# Altium schematic sheet Schematic.SchDoc
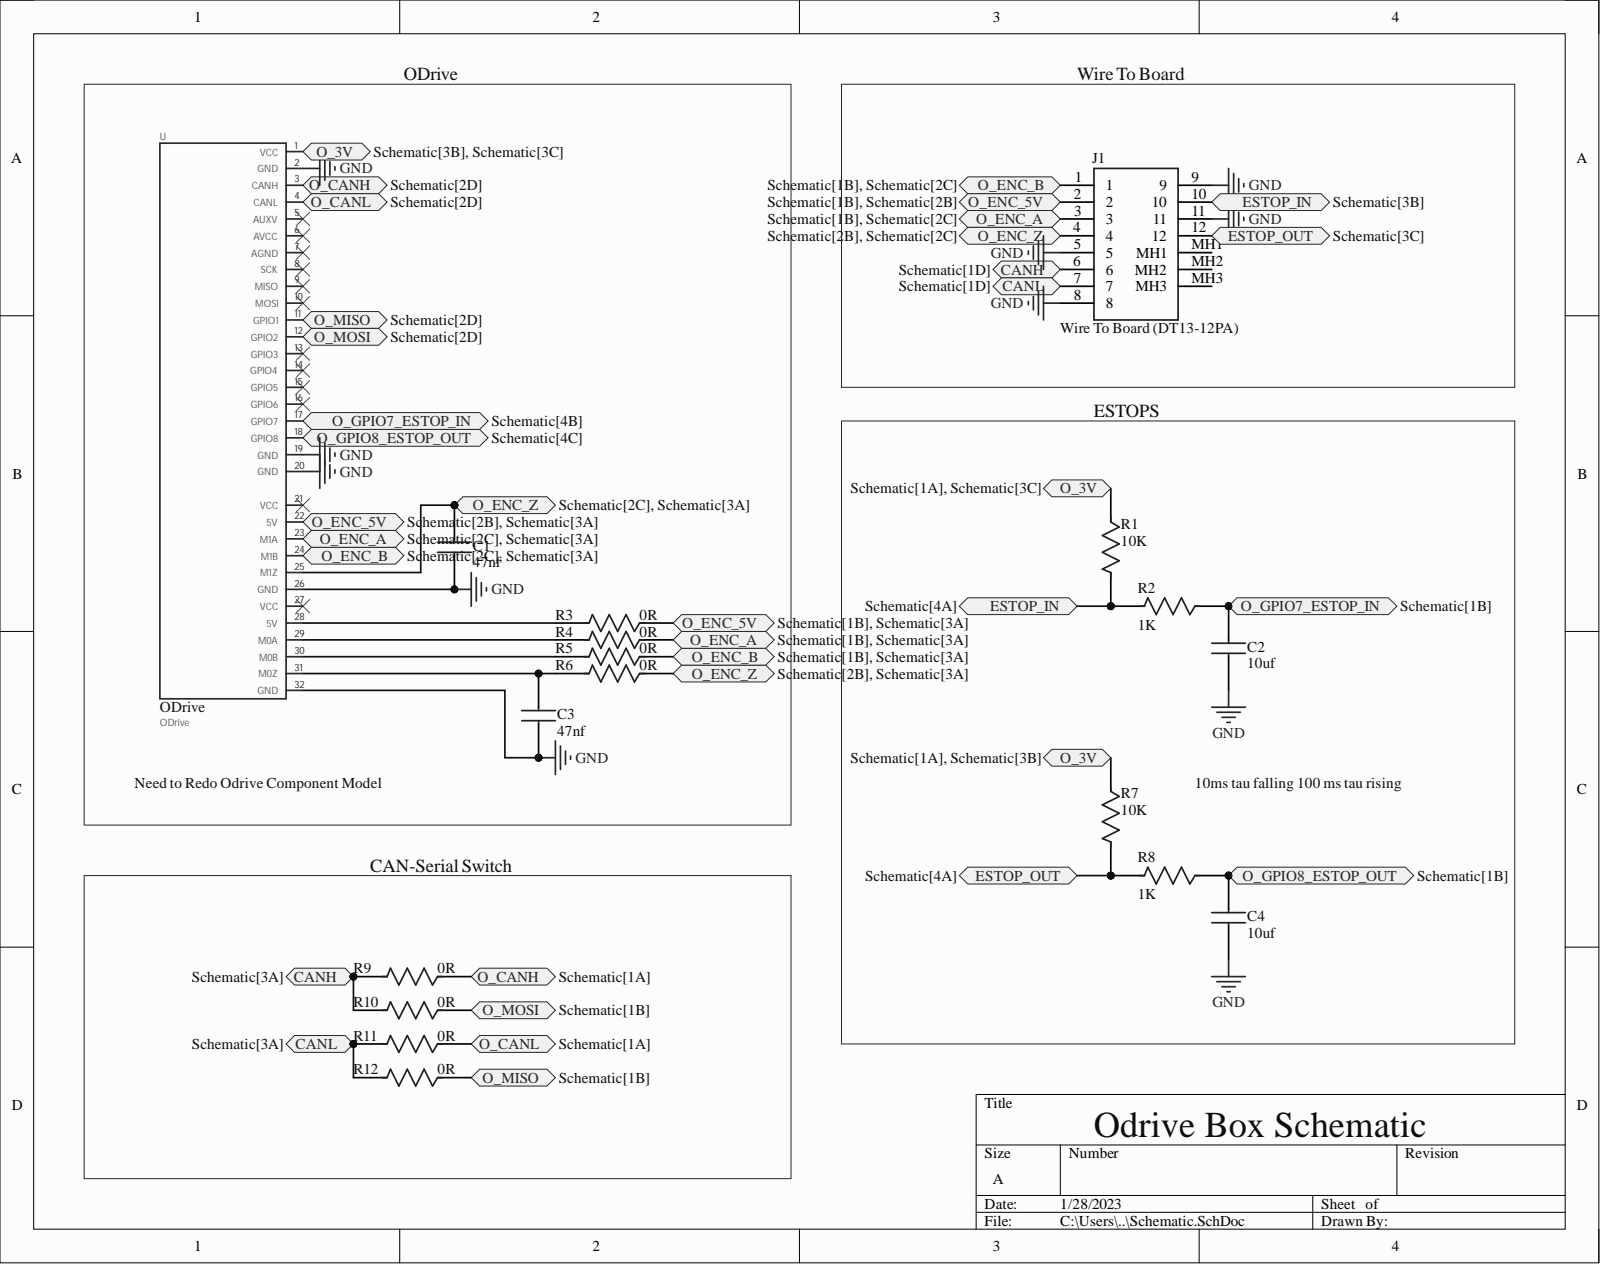
[diagram p0: Schematic.SchDoc]

## Parts
C1  47nf  [K104K10X7RF5UH5]
C2  10uf  [K104K10X7RF5UH5]
C3  47nf  [K104K10X7RF5UH5]
C4  10uf  [K104K10X7RF5UH5]
J1  Wire To Board (DT13-12PA)  [DT1312PA]
R1  10K  [FP-CFR50J2K2-MFG]
R2  1K  [FP-CFR50J2K2-MFG]
R3  0R  [FP-CFR50J2K2-MFG]
R4  0R  [FP-CFR50J2K2-MFG]
R5  0R  [FP-CFR50J2K2-MFG]
R6  0R  [FP-CFR50J2K2-MFG]
R7  10K  [FP-CFR50J2K2-MFG]
R8  1K  [FP-CFR50J2K2-MFG]
R9  0R  [FP-CFR50J2K2-MFG]
R10  0R  [FP-CFR50J2K2-MFG]
R11  0R  [FP-CFR50J2K2-MFG]
R12  0R  [FP-CFR50J2K2-MFG]
U  ODrive  [ODrive-Footprint-1]

## Ports
CANH, CANL, ESTOP_IN, ESTOP_OUT, O_3V, O_CANH, O_CANL, O_ENC_5V, O_ENC_A, O_ENC_B, O_ENC_Z, O_GPIO7_ESTOP_IN, O_GPIO8_ESTOP_OUT, O_MISO, O_MOSI

## Nets
GND: C1.1 C2.2 C3.1 C4.2 J1.5 J1.8 J1.9 J1.11 U.2(GND) U.19(GND) U.20(GND) U.26(GND) U.32(GND)
CANH: J1.6 R9.2 R10.2 ; port CANH
CANL: J1.7 R11.2 R12.2 ; port CANL
ESTOP_IN: J1.10 R1.2 R2.2 ; port ESTOP_IN
ESTOP_OUT: J1.12 R7.2 R8.2 ; port ESTOP_OUT
O_3V: R1.1 R7.1 U.1(VCC) ; port O_3V
O_CANH: R9.1 U.3(CANH) ; port O_CANH
O_CANL: R11.1 U.4(CANL) ; port O_CANL
O_ENC_5V: J1.2 R3.1 U.22(5V) ; port O_ENC_5V
O_ENC_A: J1.3 R4.1 U.23(M1A) ; port O_ENC_A
O_ENC_B: J1.1 R5.1 U.24(M1B) ; port O_ENC_B
O_ENC_Z: C1.2 J1.4 R6.1 U.25(M1Z) ; port O_ENC_Z
O_GPIO7_ESTOP_IN: C2.1 R2.1 U.17(GPIO7) ; port O_GPIO7_ESTOP_IN
O_GPIO8_ESTOP_OUT: C4.1 R8.1 U.18(GPIO8) ; port O_GPIO8_ESTOP_OUT
O_MISO: R12.1 U.11(GPIO1) ; port O_MISO
O_MOSI: R10.1 U.12(GPIO2) ; port O_MOSI
NetC3_2: C3.2 R6.2 U.31(M0Z)
NetR3_2: R3.2 U.28(5V)
NetR4_2: R4.2 U.29(M0A)
NetR5_2: R5.2 U.30(M0B)

Not connected: J1 MH1 MH2 MH3; U 5(AUXV) 6(AVCC) 7(AGND) 8(SCK) 9(MISO) 10(MOSI) 13(GPIO3) 14(GPIO4) 15(GPIO5) 16(GPIO6) 21(VCC) 27(VCC)

## Library data of the parts
C1-C4 = CMP-019-000000-1: VISHAY - K104K10X7RF5UH5 - CAP, 0.1F, 50V, 10%, X7R; Geometry.Height: 6.2mm; Arrow Part Number: K104K10X7RF5UH5; Arrow Price/Stock: https://www.arrow.com/en/products/k104k10x7rf5uh5/vishay?region=nac; Component Kind: Standard; Datasheet Link: https://www.vishay.com/docs/45171/kseries.pdf; Design Item ID: K104K10X7RF5UH5; Manufacturer_Name: Vishay; Manufacturer_Part_Number: K104K10X7RF5UH5; Mouser Part Number: 594-K104K10X7RF5UH5; Mouser Price/Stock: https://www.mouser.co.uk/ProductDetail/Vishay-BC-Components/K104K10X7RF5UH5?qs=rLgk8CAOBHbAqIwDswVbzA%3D%3D; Source: K104K10X7RF5UH5.SchLib; Library Name: Bruin Racing Baja
J1 = CMP-016-000000-1: Automotive Connectors 12P DT 90DEG RECEP HEADER; Manufacturer_Name: TE Connectivity; Geometry.Height: 35.26mm; Arrow Part Number: DT13-12PA; Arrow Price/Stock: https://www.arrow.com/en/products/dt13-12pa/te-connectivity; Component Kind: Standard; Datasheet Link: https://componentsearchengine.com/Datasheets/1/DT13-12PA.pdf; Design Item ID: DT13-12PA; Manufacturer_Part_Number: DT13-12PA; Mouser Part Number: 571-DT13-12PA; Mouser Price/Stock: https://www.mouser.co.uk/ProductDetail/TE-Connectivity-DEUTSCH/DT13-12PA?qs=vkMqrgFpRahFscUAzs597g%3D%3D; Source: DT13-12PA.SchLib; Library Name: Bruin Racing Baja
R1-R12 = CMP-03212-000011-1: Max Power Dissipation: 500mW; Number of Resistors: 1; Resistance: 2.2kR; Resistance Tolerance: 5%; Temperature Coefficient: 0ppm/°C; Voltage Rating: 350V; Case/Package: Axial; Component Kind: Standard; Diameter: 3mm; ELV: Compliant; Length: 9mm; Library Name: Altium Content Vault; Max Operating Temperature: 155°C; Min Operating Temperature: -55°C; Mount: Axial; Number of Terminations: 2; Package Quantity: 3000; Packaging: Cut Tape; Power Rating: 500mW; Radiation Hardening: No; REACH SVHC: No SVHC; Resistor Type: Thin Film; RoHS Compliant: Yes; Series: CFR50, Neohm; Technology: CARBON FILM; Termination: Axial; Tolerance: 5%; Voltage Rating (DC): 350V
U = CMP-013-000000-7: Component Kind: Standard; Design Item ID: ODrive; Value: ODrive; Library Name: Bruin Racing Baja; Source: baja.SchLib
